# Altium schematic sheet ESP32_SCHEMATIC.SchDoc
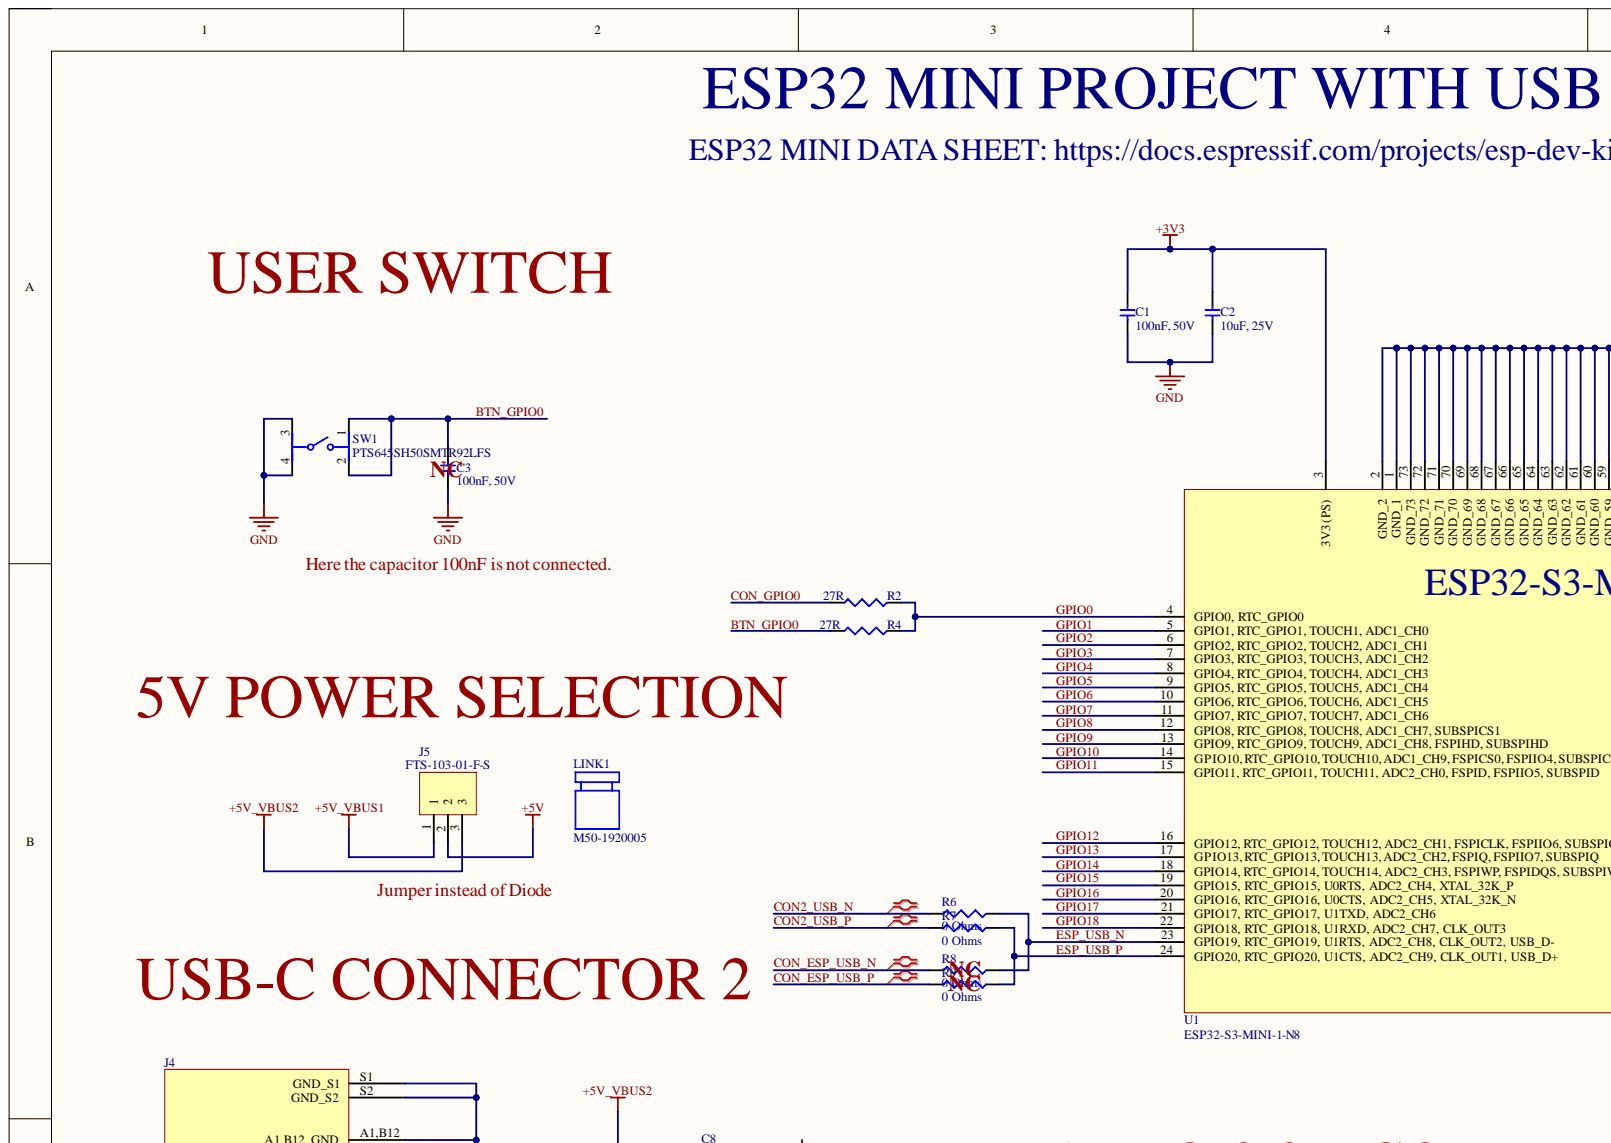
[diagram p0: ESP32_SCHEMATIC.SchDoc, top left]
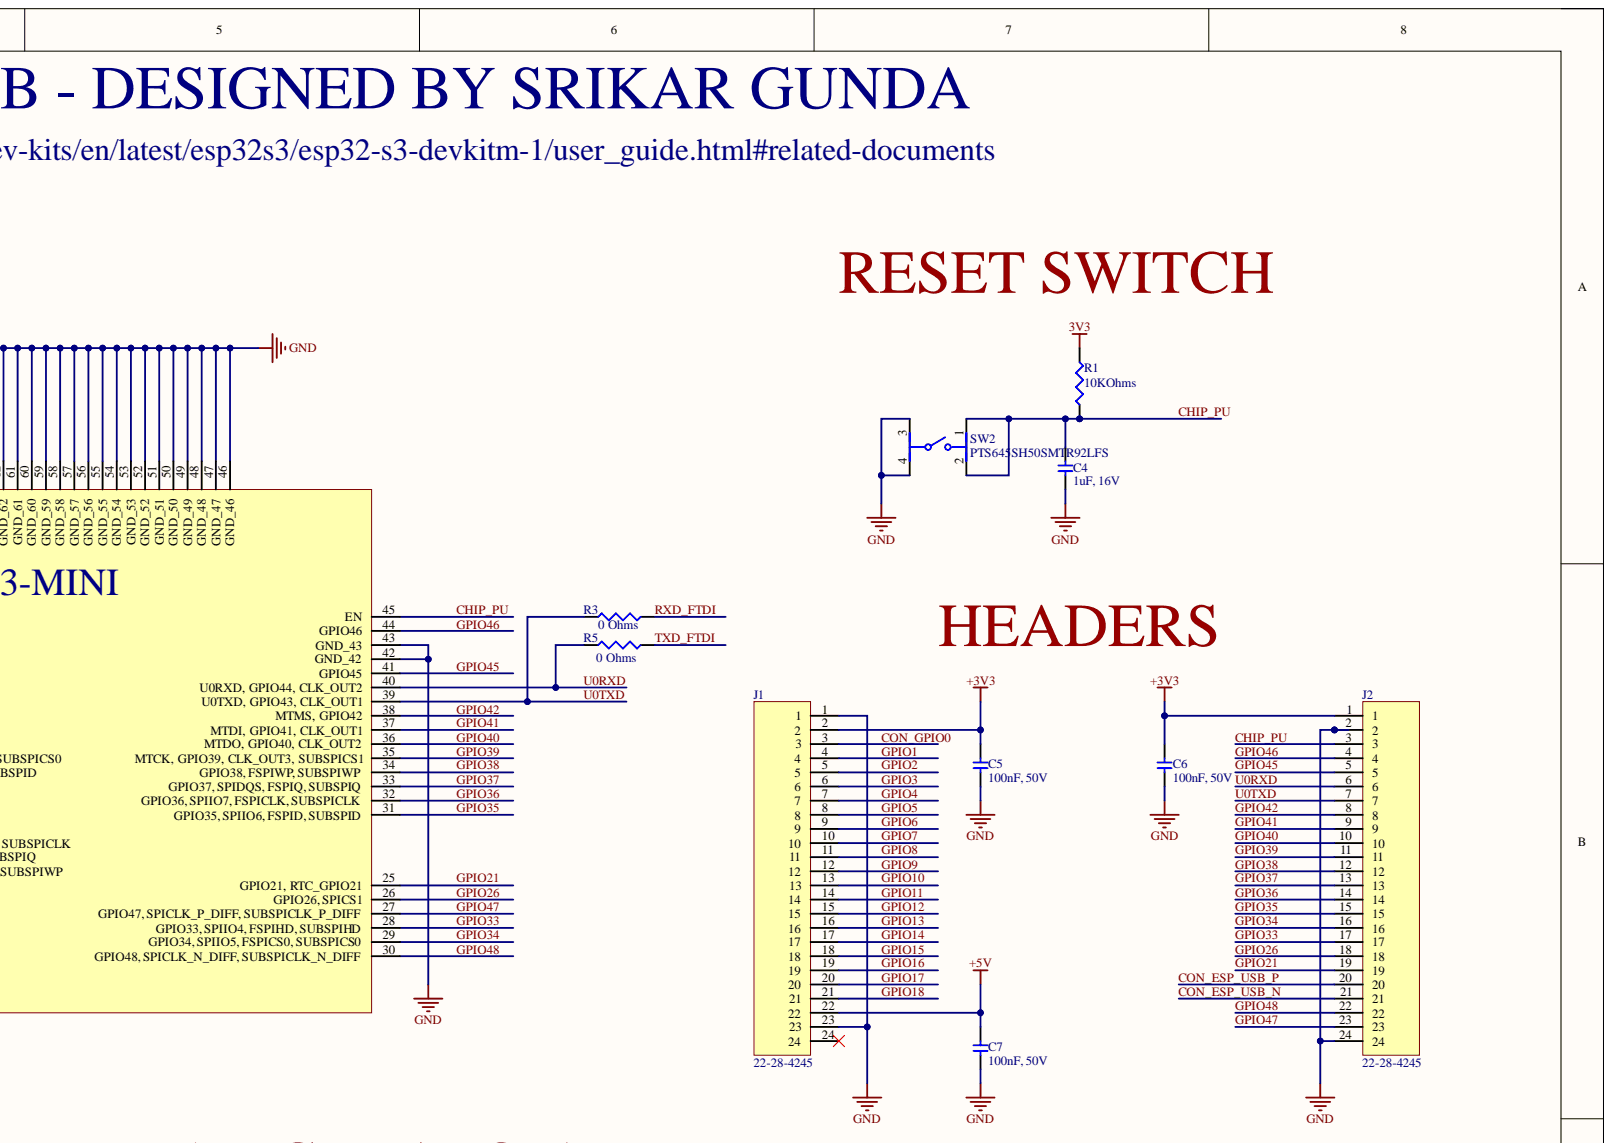
[diagram p1: ESP32_SCHEMATIC.SchDoc, top right]
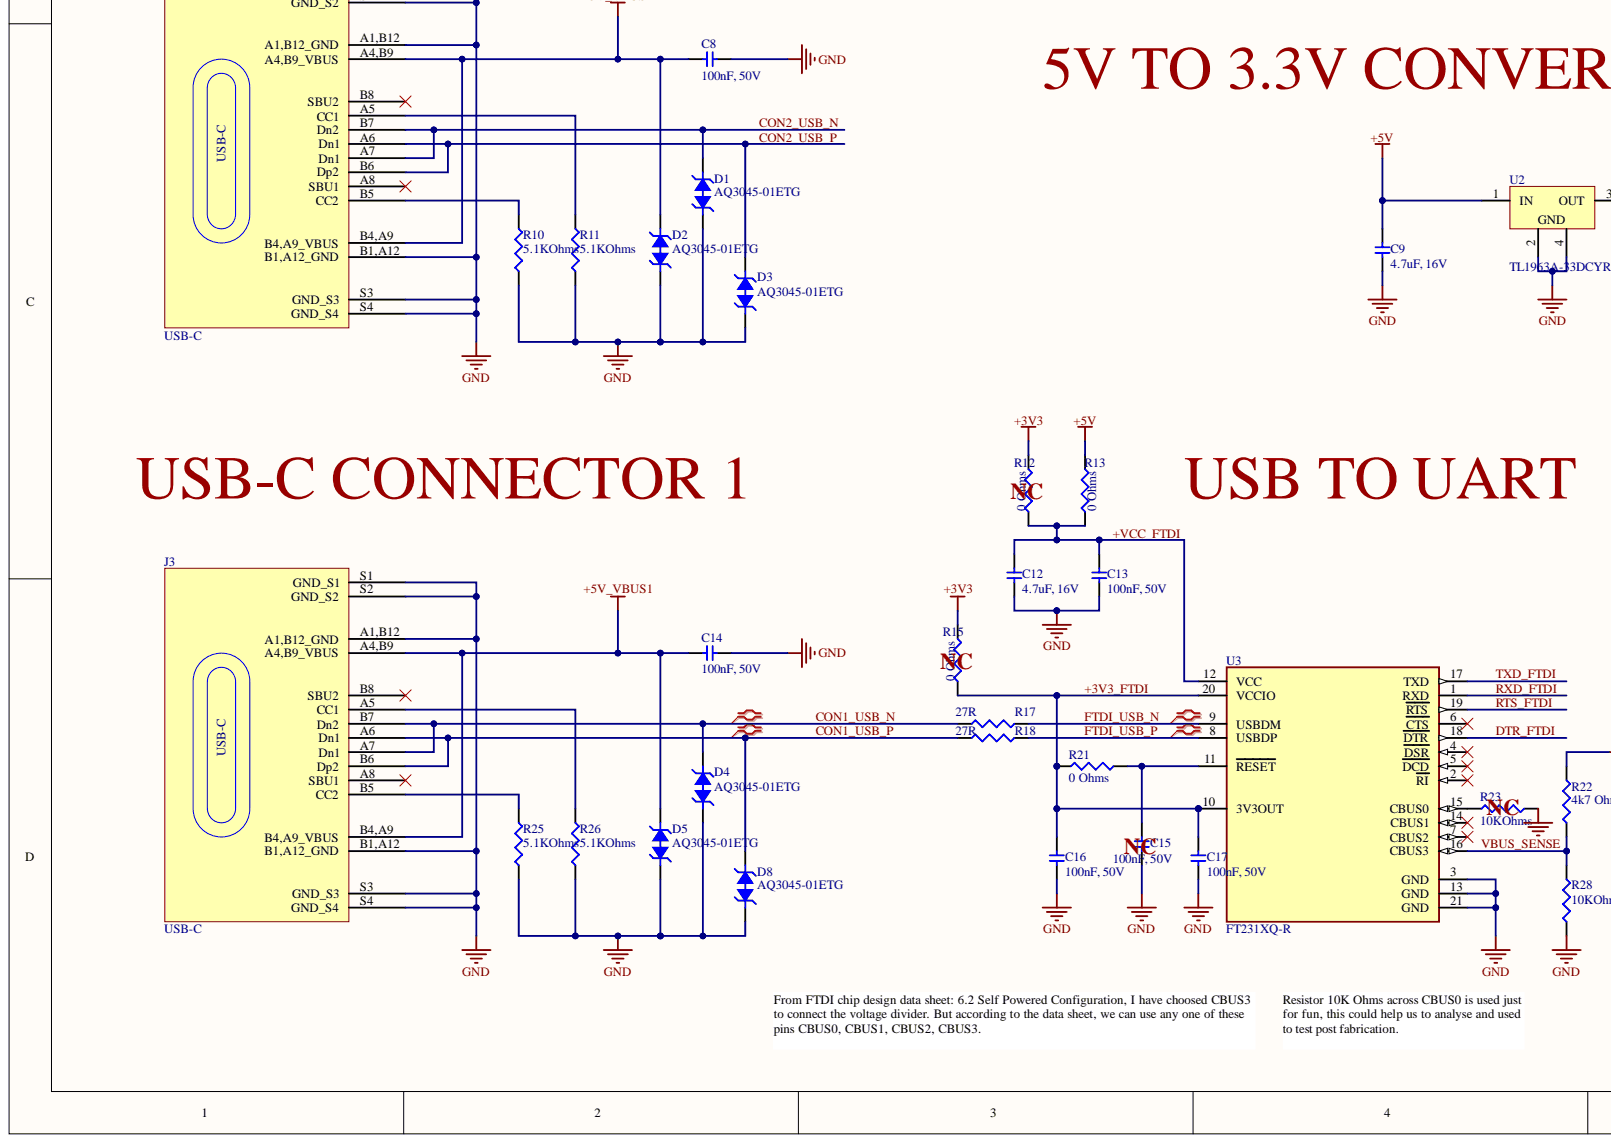
[diagram p2: ESP32_SCHEMATIC.SchDoc, bottom left]
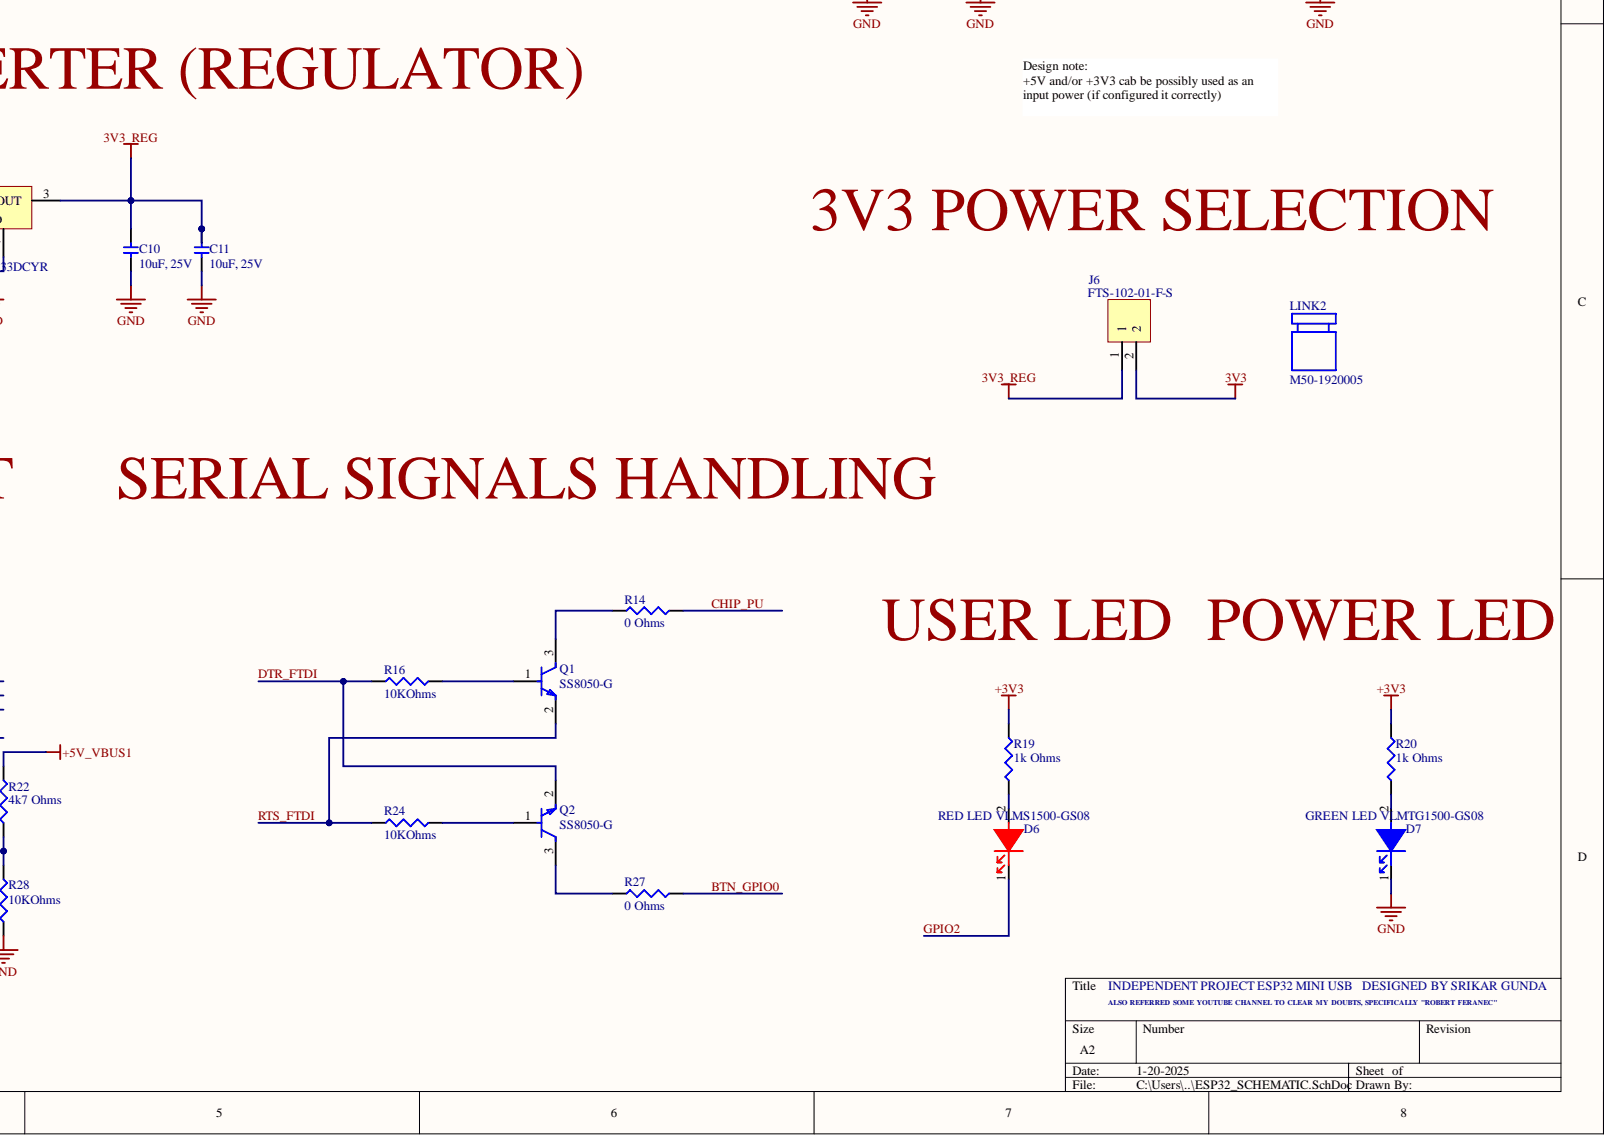
[diagram p3: ESP32_SCHEMATIC.SchDoc, bottom right]

## Parts
C1  100nF, 50V
C2  10uF, 25V  Rated DC Voltage (URdc): 25V
C3  100nF, 50V
C4  1uF, 16V  Rated DC Voltage (URdc): 16V
C5  100nF, 50V
C6  100nF, 50V
C7  100nF, 50V
C8  100nF, 50V
C9  4.7uF, 16V  Rated DC Voltage (URdc): 16V
C10  10uF, 25V
C11  10uF, 25V  Rated DC Voltage (URdc): 25V
C12  4.7uF, 16V
C13  100nF, 50V
C14  100nF, 50V
C15  100nF, 50V
C16  100nF, 50V
C17  100nF, 50V
D1  AQ3045-01ETG
D2  AQ3045-01ETG
D3  AQ3045-01ETG
D4  AQ3045-01ETG
D5  AQ3045-01ETG
D6  RED LED VLMS1500-GS08
D7  GREEN LED VLMTG1500-GS08
D8  AQ3045-01ETG
J1  22-28-4245
J2  22-28-4245
J3  USB-C  [FP-2171790001-MFG]
J4  USB-C  [FP-2171790001-MFG]
J5  FTS-103-01-F-S
J6  FTS-102-01-F-S
LINK1  M50-1920005
LINK2  M50-1920005
Q1  SS8050-G  [FP-SS8050-G-IPC_B]
Q2  SS8050-G  [FP-SS8050-G-IPC_B]
R1  10KOhms
R2  27R
R3  0 Ohms
R4  27R
R5  0 Ohms
R6  0 Ohms
R7  0 Ohms
R8  0 Ohms
R9  0 Ohms
R10  5.1KOhms
R11  5.1KOhms
R12  0 Ohms
R13  0 Ohms
R14  0 Ohms
R15  0 Ohms
R16  10KOhms
R17  27R
R18  27R
R19  1k Ohms
R20  1k Ohms
R21  0 Ohms
R22  4k7 Ohms
R23  10KOhms
R24  10KOhms
R25  5.1KOhms
R26  5.1KOhms
R27  0 Ohms
R28  10KOhms
SW1  PTS645SH50SMTR92LFS  [FP-PTS645SH50SMTR92LFS-MFG]
SW2  PTS645SH50SMTR92LFS  [FP-PTS645SH50SMTR92LFS-MFG]
U1  ESP32-S3-MINI-1-N8
U2  TL1963A-33DCYR  [DCY0004A_N]
U3  FT231XQ-R  [FP-QFN-20-IPC_B]

## Nets
3V3_REG: C10.2 C11.2 J6.1 U2.3(OUT)
+5V: C7.2 C9.2 J1.22 J5.2 R13.2 U2.1(IN)
+5V_VBUS1: C14.2 D5.2 J3.A4,B9(A4,B9_VBUS) J3.B4,A9(B4,A9_VBUS) J5.1 R22.2
+5V_VBUS2: C8.2 D2.2 J4.A4,B9(A4,B9_VBUS) J4.B4,A9(B4,A9_VBUS) J5.3
GND: C1.1 C2.1 C3.1 C4.1 C5.1 C6.1 C7.1 C8.1 C9.1 C10.1 C11.1 C12.1 C13.1 C14.1 C15.1 C16.1 C17.1 D1.1 D2.1 D3.1 D4.1 D5.1 D7.1 D8.1 J1.1 J1.23 J2.2 J2.24 J3.A1,B12(A1,B12_GND) J3.B1,A12(B1,A12_GND) J3.S1(GND_S1) J3.S2(GND_S2) J3.S3(GND_S3) J3.S4(GND_S4) J4.A1,B12(A1,B12_GND) J4.B1,A12(B1,A12_GND) J4.S1(GND_S1) J4.S2(GND_S2) J4.S3(GND_S3) J4.S4(GND_S4) R10.2 R11.2 R23.1 R25.2 R26.2 R28.1 SW1.3 SW1.4 SW2.3 SW2.4 U1.1(GND_1) U1.2(GND_2) U1.42(GND_42) U1.43(GND_43) U1.46(GND_46) U1.47(GND_47) U1.48(GND_48) U1.49(GND_49) U1.50(GND_50) U1.51(GND_51) U1.52(GND_52) U1.53(GND_53) U1.54(GND_54) U1.55(GND_55) U1.56(GND_56) U1.57(GND_57) U1.58(GND_58) U1.59(GND_59) U1.60(GND_60) U1.61(GND_61) U1.62(GND_62) U1.63(GND_63) U1.64(GND_64) U1.65(GND_65) U1.66(GND_66) U1.67(GND_67) U1.68(GND_68) U1.69(GND_69) U1.70(GND_70) U1.71(GND_71) U1.72(GND_72) U1.73(GND_73) U2.2 U2.4 U3.3(GND) U3.13(GND) U3.21(GND)
3V3: C1.2 C2.2 C5.2 C6.2 C16.2 C17.2 J1.2 J2.1 J6.2 R1.2 R12.2 R15.1 R15.2 R19.2 R20.2 R21.1 U1.3(3V3_(PS)) U3.10(3V3OUT) U3.20(VCCIO)  [also labelled +3V3_FTDI]
+VCC_FTDI: C12.2 C13.2 R12.1 R13.1 U3.12(VCC)
BTN_GPIO0: C3.2 R4.1 R27.2 SW1.1 SW1.2
CHIP_PU: C4.2 J2.3 R1.1 R14.2 SW2.1 SW2.2 U1.45(EN)
CON1_USB_N: D4.2 J3.A7(Dn1) J3.B7(Dn2) R17.1
CON1_USB_P: D8.2 J3.A6(Dn1) J3.B6(Dp2) R18.1
CON2_USB_N: D1.2 J4.A7(Dn1) J4.B7(Dn2) R6.1
CON2_USB_P: D3.2 J4.A6(Dn1) J4.B6(Dp2) R7.1
CON_ESP_USB_N: J2.21 R8.1
CON_ESP_USB_P: J2.20 R9.1
CON_GPIO0: J1.3 R2.1
DTR_FTDI: Q2.2 R16.1 U3.18(DTR)
ESP_USB_N: R6.2 R8.2 U1.23(GPIO19,_RTC_GPIO19,_U1RTS,_ADC2_CH8,_CLK_OUT2,_USB_D-)
ESP_USB_P: R7.2 R9.2 U1.24(GPIO20,_RTC_GPIO20,_U1CTS,_ADC2_CH9,_CLK_OUT1,_USB_D+)
FTDI_USB_N: R17.2 U3.9(USBDM)
FTDI_USB_P: R18.2 U3.8(USBDP)
GPIO0: R2.2 R4.2 U1.4(GPIO0,_RTC_GPIO0)
GPIO1: J1.4 U1.5(GPIO1,_RTC_GPIO1,_TOUCH1,_ADC1_CH0)
GPIO2: D6.1 J1.5 U1.6(GPIO2,_RTC_GPIO2,_TOUCH2,_ADC1_CH1)
GPIO3: J1.6 U1.7(GPIO3,_RTC_GPIO3,_TOUCH3,_ADC1_CH2)
GPIO4: J1.7 U1.8(GPIO4,_RTC_GPIO4,_TOUCH4,_ADC1_CH3)
GPIO5: J1.8 U1.9(GPIO5,_RTC_GPIO5,_TOUCH5,_ADC1_CH4)
GPIO6: J1.9 U1.10(GPIO6,_RTC_GPIO6,_TOUCH6,_ADC1_CH5)
GPIO7: J1.10 U1.11(GPIO7,_RTC_GPIO7,_TOUCH7,_ADC1_CH6)
GPIO8: J1.11 U1.12(GPIO8,_RTC_GPIO8,_TOUCH8,_ADC1_CH7,_SUBSPICS1)
GPIO9: J1.12 U1.13(GPIO9,_RTC_GPIO9,_TOUCH9,_ADC1_CH8,_FSPIHD,_SUBSPIHD)
GPIO10: J1.13 U1.14
GPIO11: J1.14 U1.15
GPIO12: J1.15 U1.16(GPIO12,_RTC_GPIO12,_TOUCH12,_ADC2_CH1,_FSPICLK,_FSPIIO6,_SUBSPICLK)
GPIO13: J1.16 U1.17(GPIO13,_RTC_GPIO13,_TOUCH13,_ADC2_CH2,_FSPIQ,_FSPIIO7,_SUBSPIQ)
GPIO14: J1.17 U1.18(GPIO14,_RTC_GPIO14,_TOUCH14,_ADC2_CH3,_FSPIWP,_FSPIDQS,_SUBSPIWP)
GPIO15: J1.18 U1.19(GPIO15,_RTC_GPIO15,_U0RTS,_ADC2_CH4,_XTAL_32K_P)
GPIO16: J1.19 U1.20(GPIO16,_RTC_GPIO16,_U0CTS,_ADC2_CH5,_XTAL_32K_N)
GPIO17: J1.20 U1.21(GPIO17,_RTC_GPIO17,_U1TXD,_ADC2_CH6)
GPIO18: J1.21 U1.22(GPIO18,_RTC_GPIO18,_U1RXD,_ADC2_CH7,_CLK_OUT3)
GPIO21: J2.19 U1.25(GPIO21,_RTC_GPIO21)
GPIO26: J2.18 U1.26(GPIO26,_SPICS1)
GPIO33: J2.17 U1.28(GPIO33,_SPIIO4,_FSPIHD,_SUBSPIHD)
GPIO34: J2.16 U1.29(GPIO34,_SPIIO5,_FSPICS0,_SUBSPICS0)
GPIO35: J2.15 U1.31(GPIO35,_SPIIO6,_FSPID,_SUBSPID)
GPIO36: J2.14 U1.32(GPIO36,_SPIIO7,_FSPICLK,_SUBSPICLK)
GPIO37: J2.13 U1.33(GPIO37,_SPIDQS,_FSPIQ,_SUBSPIQ)
GPIO38: J2.12 U1.34(GPIO38,_FSPIWP,_SUBSPIWP)
GPIO39: J2.11 U1.35(MTCK,_GPIO39,_CLK_OUT3,_SUBSPICS1)
GPIO40: J2.10 U1.36(MTDO,_GPIO40,_CLK_OUT2)
GPIO41: J2.9 U1.37(MTDI,_GPIO41,_CLK_OUT1)
GPIO42: J2.8 U1.38(MTMS,_GPIO42)
GPIO45: J2.5 U1.41(GPIO45)
GPIO46: J2.4 U1.44(GPIO46)
GPIO47: J2.23 U1.27(GPIO47,_SPICLK_P_DIFF,_SUBSPICLK_P_DIFF)
GPIO48: J2.22 U1.30(GPIO48,_SPICLK_N_DIFF,_SUBSPICLK_N_DIFF)
RTS_FTDI: Q1.2 R24.1 U3.19(RTS)
RXD_FTDI: R3.2 U3.1(RXD)
TXD_FTDI: R5.2 U3.17(TXD)
U0RXD: J2.6 R5.1 U1.40(U0RXD,_GPIO44,_CLK_OUT2)
U0TXD: J2.7 R3.1 U1.39(U0TXD,_GPIO43,_CLK_OUT1)
VBUS_SENSE: R22.1 R28.2 U3.16(CBUS3)
NetC15_2: C15.2 R21.2 U3.11(RESET)
NetD6_2: D6.2 R19.1
NetD7_2: D7.2 R20.1
NetJ3_A5: J3.A5(CC1) R26.1
NetJ3_B5: J3.B5(CC2) R25.1
NetJ4_A5: J4.A5(CC1) R11.1
NetJ4_B5: J4.B5(CC2) R10.1
NetQ1_1: Q1.1 R16.2
NetQ1_3: Q1.3 R14.1
NetQ2_1: Q2.1 R24.2
NetQ2_3: Q2.3 R27.1
NetR23_2: R23.2 U3.15(CBUS0)

Not connected: J1 24; J3 A8(SBU1) B8(SBU2); J4 A8(SBU1) B8(SBU2); U3 2(RI) 4(DSR) 5(DCD) 6(CTS) 7(CBUS2) 14(CBUS1)
